# Altium schematic sheet GPS.SchDoc
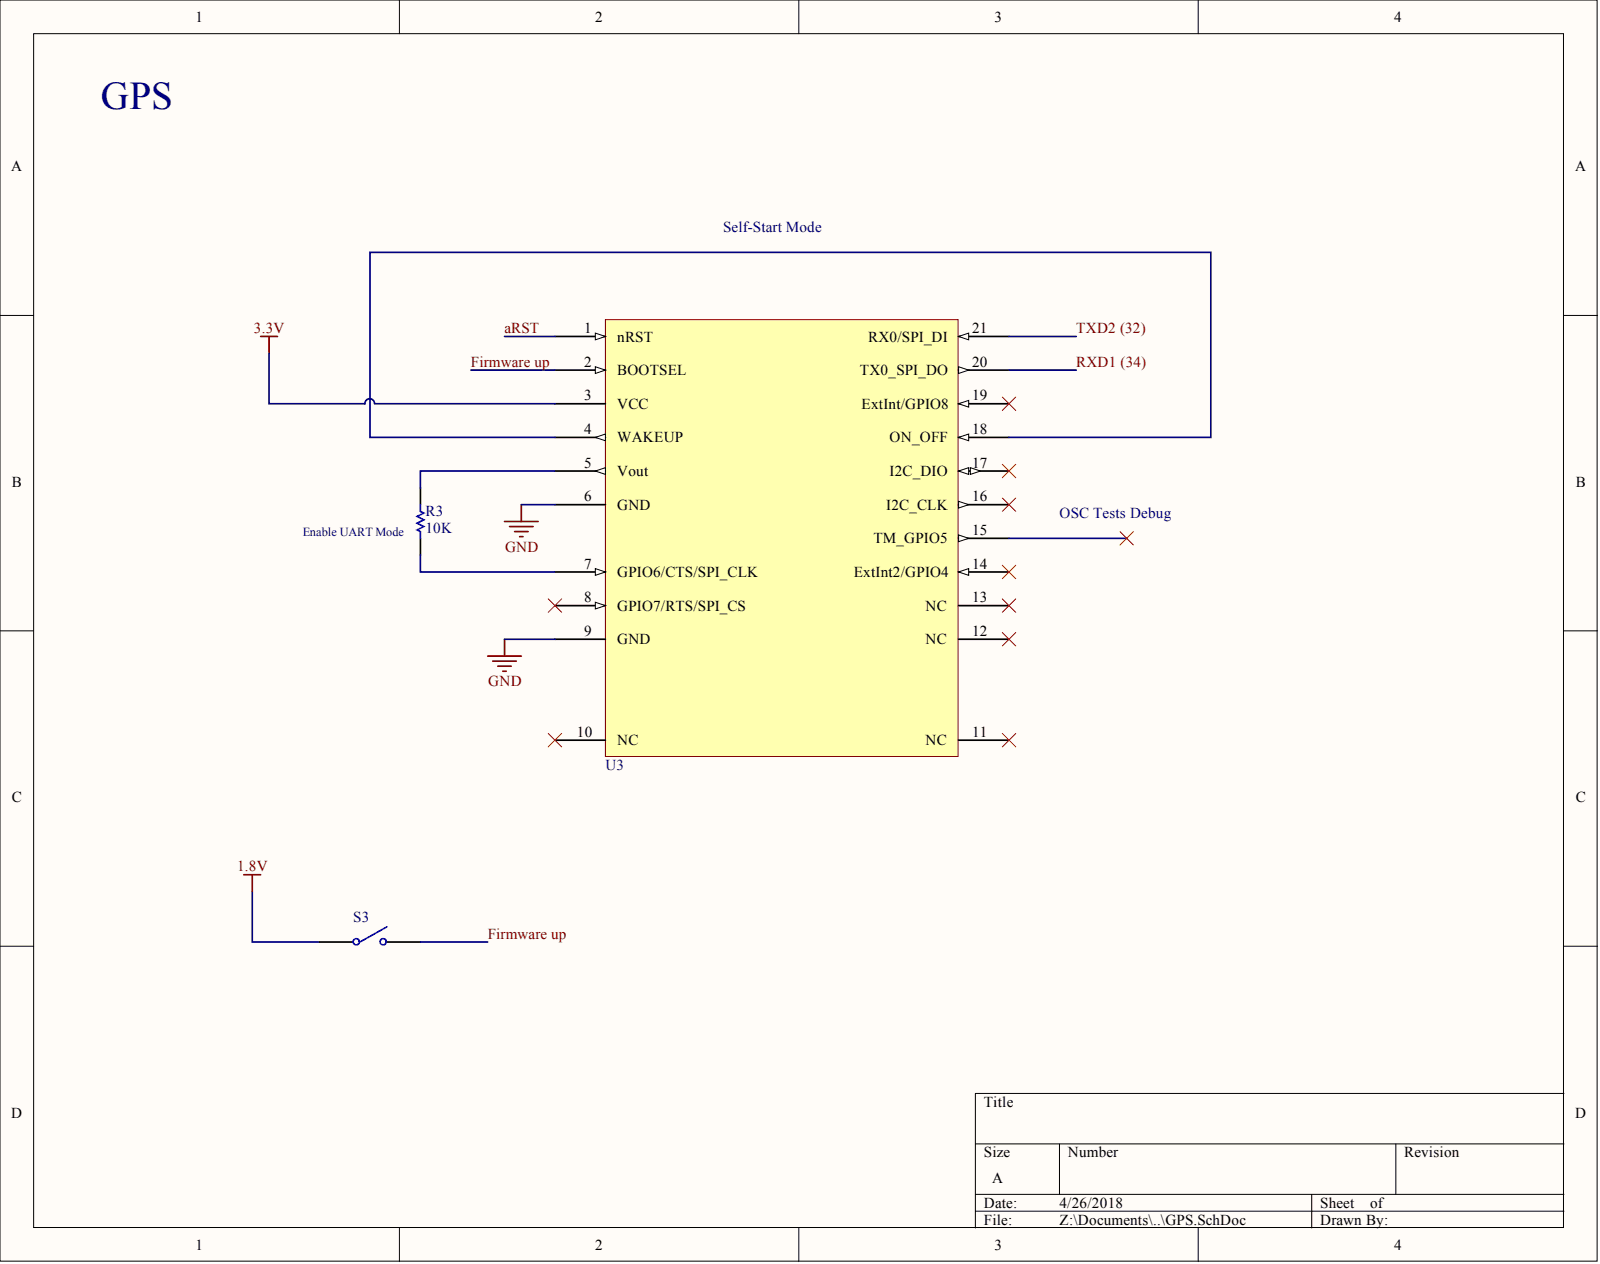
[diagram p0: GPS.SchDoc]

## Parts
R3  10K  [AXIAL-0.3]
S3  Single-Pole, Single-Throw Switch  [SPST-2]  (value not drawn)
U3  A2035-H  (value not drawn)

## Nets
1.8V: S3.1
3.3V: U3.3(VCC)
GND: U3.6(GND) U3.9(GND)
ARST: U3.1(nRST)
FIRMWARE UP: S3.2 U3.2(BOOTSEL)
RXD1 (34): U3.20(TX0_SPI_DO)
TXD2 (32): U3.21(RX0/SPI_DI)
NetR3_1: R3.1 U3.7(GPIO6/CTS/SPI_CLK)
NetR3_2: R3.2 U3.5(Vout)
NetU3_4: U3.4(WAKEUP) U3.18(ON_OFF)

Not connected: U3 8(GPIO7/RTS/SPI_CS) 10(NC) 11(NC) 12(NC) 13(NC) 14(ExtInt2/GPIO4) 15(TM_GPIO5) 16(I2C_CLK) 17(I2C_DIO) 19(ExtInt/GPIO8)

## Library data of the parts
R3 = Res1: Resistor; Component Kind: Standard; LatestRevisionDate: 17-Jul-2002; LatestRevisionNote: Re-released for DXP Platform.; Library Name: Miscellaneous Devices.IntLib; PackageDescription: Axial Device, Thru-Hole; 2 Leads; 0.3 in Pin Spacing; PackageReference: AXIAL-0.3; Published: 8-Jun-2000; Signal Integrity: Res; Simulation: RESISTOR; Publisher: Altium Limited
S3 = SW-SPST: GPS Firmware; Component Kind: Standard; LatestRevisionDate: 17-Jul-2002; LatestRevisionNote: Re-released for DXP Platform.; Library Name: Miscellaneous Devices.IntLib; PackageDescription: Switch; 2 Leads; PackageReference: SPST-2; Published: 24-Mar-1999; Publisher: Altium Limited
U3 = A2035-H: GPS Module; Fabricant: MAESTRO WIRELESS SOLUTIONS; Fournisseur: Farnell; RefFabricant: A2035H; RefFournisseur: 2281693; Component Kind: Standard; Library Name: Schlib.SchLib
